# Altium schematic sheet [2]-CPLD-XC95144.SchDoc
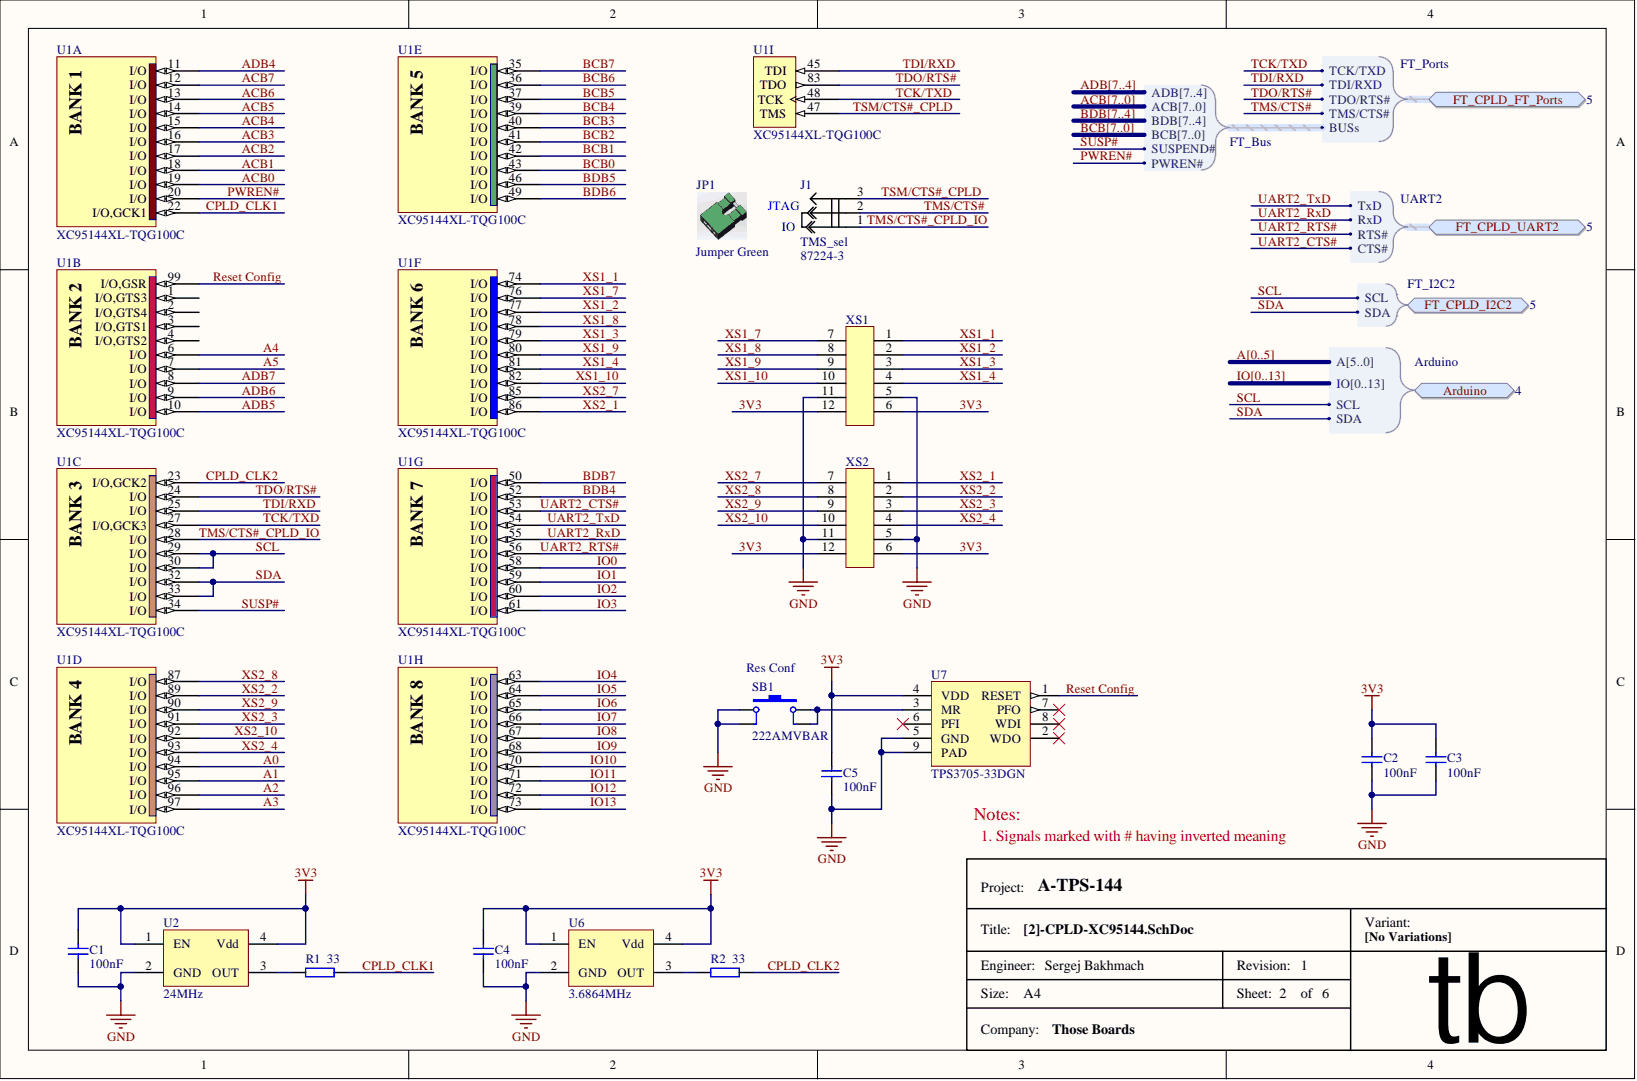
[diagram p0: [2]-CPLD-XC95144.SchDoc]

## Parts
C1  value 100nF  [C0603]  (hidden comment: CAP)
C2  value 100nF  [C0603]  (hidden comment: CAP)
C3  value 100nF  [C0603]  (hidden comment: CAP)
C4  value 100nF  [C0603]  (hidden comment: CAP)
C5  value 100nF  [C0603]  (hidden comment: CAP)
J1  TMS_sel  value 87224-3  Manufacturer Part: 87224-3  [Jumper_3pin_green]
JP1  value Jumper Green  Supplier Part: Jumper  (hidden comment: VCC_sel)  (mechanical)
R1  value 33  [0603_RESISTOR]  (hidden comment: Resistor)
R2  value 33  [0603_RESISTOR]  (hidden comment: Resistor)
SB1  Res Conf  value 222AMVBAR  Manufacturer Part: 222AMVBAR  [Key 6.2x6.2]
U1  value XC95144XL-TQG100C  Supplier Part: XC95144XL-TQG100C  [TQ100 - XC95144XL]  (hidden comment: XC95144XL-SQ100)  (parts A,B,C,D,E,F,G,H,I)
U2  value 24MHz  [OSC_5x7]  (hidden comment: OSC_Crystal Oscillator)
U6  value 3.6864MHz  Manufacturer Part: 3.6864MHz  [OSC_5x7]  (hidden comment: OSC_Crystal Oscillator)
U7  TPS3705-33DGN  [DGNR_pwrpad]
XS1  DG2_conn_pmod_angle_m  [IDC2X6M_PMOD]  (value not drawn)
XS2  DG2_conn_pmod_angle_m  [IDC2X6M_PMOD]  (value not drawn)

## Ports
ARDUINO, ARDUINO.A0, ARDUINO.A1, ARDUINO.A2, ARDUINO.A3, ARDUINO.A4, ARDUINO.A5, ARDUINO.A[5..0], ARDUINO.IO0, ARDUINO.IO1, ARDUINO.IO2, ARDUINO.IO3, ARDUINO.IO4, ARDUINO.IO5, ARDUINO.IO6, ARDUINO.IO7, ARDUINO.IO8, ARDUINO.IO9, ARDUINO.IO10, ARDUINO.IO11, ARDUINO.IO12, ARDUINO.IO13, ARDUINO.IO[0..13], ARDUINO.SCL, ARDUINO.SDA, FT_CPLD_FT_PORTS, FT_CPLD_FT_PORTS.BUSS.ACB0, FT_CPLD_FT_PORTS.BUSS.ACB1, FT_CPLD_FT_PORTS.BUSS.ACB2, FT_CPLD_FT_PORTS.BUSS.ACB3, FT_CPLD_FT_PORTS.BUSS.ACB4, FT_CPLD_FT_PORTS.BUSS.ACB5, FT_CPLD_FT_PORTS.BUSS.ACB6, FT_CPLD_FT_PORTS.BUSS.ACB7, FT_CPLD_FT_PORTS.BUSS.ACB[7..0], FT_CPLD_FT_PORTS.BUSS.ADB4, FT_CPLD_FT_PORTS.BUSS.ADB5, FT_CPLD_FT_PORTS.BUSS.ADB6, FT_CPLD_FT_PORTS.BUSS.ADB7, FT_CPLD_FT_PORTS.BUSS.ADB[7..4], FT_CPLD_FT_PORTS.BUSS.BCB0, FT_CPLD_FT_PORTS.BUSS.BCB1, FT_CPLD_FT_PORTS.BUSS.BCB2, FT_CPLD_FT_PORTS.BUSS.BCB3, FT_CPLD_FT_PORTS.BUSS.BCB4, FT_CPLD_FT_PORTS.BUSS.BCB5, FT_CPLD_FT_PORTS.BUSS.BCB6, FT_CPLD_FT_PORTS.BUSS.BCB7, FT_CPLD_FT_PORTS.BUSS.BCB[7..0], FT_CPLD_FT_PORTS.BUSS.BDB4, FT_CPLD_FT_PORTS.BUSS.BDB5, FT_CPLD_FT_PORTS.BUSS.BDB6, FT_CPLD_FT_PORTS.BUSS.BDB7, FT_CPLD_FT_PORTS.BUSS.BDB[7..4], FT_CPLD_FT_PORTS.BUSS.PWREN#, FT_CPLD_FT_PORTS.BUSS.SUSPEND#, FT_CPLD_FT_PORTS.TCK/TXD, FT_CPLD_FT_PORTS.TDI/RXD, FT_CPLD_FT_PORTS.TDO/RTS#, FT_CPLD_FT_PORTS.TMS/CTS#, FT_CPLD_I2C2, FT_CPLD_I2C2.SCL, FT_CPLD_I2C2.SDA, FT_CPLD_UART2, FT_CPLD_UART2.CTS#, FT_CPLD_UART2.RTS#, FT_CPLD_UART2.RXD, FT_CPLD_UART2.TXD

## Nets
GND: C1.1 C2.1 C3.1 C4.1 C5.1 SB1.1 SB1.3 U2.2(GND) U6.2(GND) U7.5(GND) U7.9(PAD) XS1.5 XS1.11 XS2.5 XS2.11
3V3: C1.2 C2.2 C3.2 C4.2 C5.2 U2.1(EN) U2.4(Vdd) U6.1(EN) U6.4(Vdd) U7.4(VDD) XS1.6 XS1.12 XS2.6 XS2.12
A0: U1.94(I/O) ; port ARDUINO  [also labelled A]
A1: U1.95(I/O) ; port ARDUINO  [also labelled A]
A2: U1.96(I/O) ; port ARDUINO  [also labelled A]
A3: U1.97(I/O) ; port ARDUINO  [also labelled A]
A4: U1.6(I/O) ; port ARDUINO  [also labelled A]
A5: U1.7(I/O) ; port ARDUINO  [also labelled A]
ACB0: U1.19(I/O) ; port FT_CPLD_FT_PORTS  [also labelled ACB]
ACB1: U1.18(I/O) ; port FT_CPLD_FT_PORTS  [also labelled ACB]
ACB2: U1.17(I/O) ; port FT_CPLD_FT_PORTS  [also labelled ACB]
ACB3: U1.16(I/O) ; port FT_CPLD_FT_PORTS  [also labelled ACB]
ACB4: U1.15(I/O) ; port FT_CPLD_FT_PORTS  [also labelled ACB]
ACB5: U1.14(I/O) ; port FT_CPLD_FT_PORTS  [also labelled ACB]
ACB6: U1.13(I/O) ; port FT_CPLD_FT_PORTS  [also labelled ACB]
ACB7: U1.12(I/O) ; port FT_CPLD_FT_PORTS  [also labelled ACB]
ADB4: U1.11(I/O) ; port FT_CPLD_FT_PORTS  [also labelled ADB]
ADB5: U1.10(I/O) ; port FT_CPLD_FT_PORTS  [also labelled ADB]
ADB6: U1.9(I/O) ; port FT_CPLD_FT_PORTS  [also labelled ADB]
ADB7: U1.8(I/O) ; port FT_CPLD_FT_PORTS  [also labelled ADB]
BCB0: U1.43(I/O) ; port FT_CPLD_FT_PORTS  [also labelled BCB]
BCB1: U1.42(I/O) ; port FT_CPLD_FT_PORTS  [also labelled BCB]
BCB2: U1.41(I/O) ; port FT_CPLD_FT_PORTS  [also labelled BCB]
BCB3: U1.40(I/O) ; port FT_CPLD_FT_PORTS  [also labelled BCB]
BCB4: U1.39(I/O) ; port FT_CPLD_FT_PORTS  [also labelled BCB]
BCB5: U1.37(I/O) ; port FT_CPLD_FT_PORTS  [also labelled BCB]
BCB6: U1.36(I/O) ; port FT_CPLD_FT_PORTS  [also labelled BCB]
BCB7: U1.35(I/O) ; port FT_CPLD_FT_PORTS  [also labelled BCB]
BDB4: U1.52(I/O) ; port FT_CPLD_FT_PORTS  [also labelled BDB]
BDB5: U1.46(I/O) ; port FT_CPLD_FT_PORTS  [also labelled BDB]
BDB6: U1.49(I/O) ; port FT_CPLD_FT_PORTS  [also labelled BDB]
BDB7: U1.50(I/O) ; port FT_CPLD_FT_PORTS  [also labelled BDB]
CPLD_CLK1: R1.2 U1.22(I/O,GCK1)
CPLD_CLK2: R2.2 U1.23(I/O,GCK2)
IO0: U1.58(I/O) ; port ARDUINO  [also labelled IO]
IO1: U1.59(I/O) ; port ARDUINO  [also labelled IO]
IO2: U1.60(I/O) ; port ARDUINO  [also labelled IO]
IO3: U1.61(I/O) ; port ARDUINO  [also labelled IO]
IO4: U1.63(I/O) ; port ARDUINO  [also labelled IO]
IO5: U1.64(I/O) ; port ARDUINO  [also labelled IO]
IO6: U1.65(I/O) ; port ARDUINO  [also labelled IO]
IO7: U1.66(I/O) ; port ARDUINO  [also labelled IO]
IO8: U1.67(I/O) ; port ARDUINO  [also labelled IO]
IO9: U1.68(I/O) ; port ARDUINO  [also labelled IO]
IO10: U1.70(I/O) ; port ARDUINO  [also labelled IO]
IO11: U1.71(I/O) ; port ARDUINO  [also labelled IO]
IO12: U1.72(I/O) ; port ARDUINO  [also labelled IO]
IO13: U1.73(I/O) ; port ARDUINO  [also labelled IO]
PWREN#: U1.20(I/O) ; port FT_CPLD_FT_PORTS
RESET CONFIG: U1.99(I/O,GSR) U7.1(RESET)
SCL: U1.29(I/O) U1.30(I/O) ; port FT_CPLD_I2C2
SDA: U1.32(I/O) U1.33(I/O) ; port FT_CPLD_I2C2
SUSP#: U1.34(I/O) ; port FT_CPLD_FT_PORTS
TCK/TXD: U1.27(I/O,GCK3) U1.48(TCK) ; port FT_CPLD_FT_PORTS
TDI/RXD: U1.25(I/O) U1.45(TDI) ; port FT_CPLD_FT_PORTS
TDO/RTS#: U1.24(I/O) U1.83(TDO) ; port FT_CPLD_FT_PORTS
TMS/CTS#: J1.2 ; port FT_CPLD_FT_PORTS
TMS/CTS#_CPLD_IO: J1.1 U1.28(I/O)
TSM/CTS#_CPLD: J1.3 U1.47(TMS)
UART2_CTS#: U1.53(I/O) ; port FT_CPLD_UART2
UART2_RTS#: U1.56(I/O) ; port FT_CPLD_UART2
UART2_RXD: U1.55(I/O) ; port FT_CPLD_UART2
UART2_TXD: U1.54(I/O) ; port FT_CPLD_UART2
XS1_1: U1.74(I/O) XS1.1
XS1_2: U1.77(I/O) XS1.2
XS1_3: U1.79(I/O) XS1.3
XS1_4: U1.81(I/O) XS1.4
XS1_7: U1.76(I/O) XS1.7
XS1_8: U1.78(I/O) XS1.8
XS1_9: U1.80(I/O) XS1.9
XS1_10: U1.82(I/O) XS1.10
XS2_1: U1.86(I/O) XS2.1
XS2_2: U1.89(I/O) XS2.2
XS2_3: U1.91(I/O) XS2.3
XS2_4: U1.93(I/O) XS2.4
XS2_7: U1.85(I/O) XS2.7
XS2_8: U1.87(I/O) XS2.8
XS2_9: U1.90(I/O) XS2.9
XS2_10: U1.92(I/O) XS2.10
NetR1_1: R1.1 U2.3(OUT)
NetR2_1: R2.1 U6.3(OUT)
NetSB1_2: SB1.2 SB1.4 U7.3(MR)

Not connected: U1 1(I/O,GTS3) 2(I/O,GTS4) 3(I/O,GTS1) 4(I/O,GTS2); U7 2(WDO) 6(PFI) 7(PFO) 8(WDI)

## Library data of the parts
C1-C5 = CAP: Manufacturer: Vishay / Vitramon; Manufacturer Part: VJ0603Y104JXJPW1BC; Type of Component: Ceramic Capacitor; Component Kind: Standard; Manufacturer Link: http://www.vishay.com/product?docid=28548; Package / Case: 0603; Supplier: mouser; Supplier Link: http://www.mouser.com/ProductDetail/Vishay/VJ0603Y104JXJPW1BC/?qs=%2fha2pyFaduidGoO0X7aK7Xgs%2fjxfhnLiK6SRw4Lz0%252bZK3PJ8DddTrQ%3d%3d; Supplier Part: 77-VJ0603Y104JXJPBC; Library Name: RPI-VITA.IntLib
J1 = jumper_3pin: Manufacturer: TE Connectivity / AMP; Type of Component: Jumper; Component Kind: Standard; Manufacturer Link: http://www.te.com/usa-en/product-87224-3.html#pdp-related-products-inner; Package / Case: Header 1 x 3; Supplier: mouser; Supplier Link: http://www.mouser.ee/ProductDetail/TE-Connectivity-AMP/87224-3/?qs=sGAEpiMZZMs%252bGHln7q6pm48SVpWlpfsErguO%2fp0873w%3d; Supplier Part: 571-872243; Library Name: RPI-VITA.IntLib
JP1 = jumper green: Manufacturer: Great Electric; Manufacturer Part: Jumper Cap Shunts Short Circuit Cap; Package / Case: 2.54mm; Supplier: aliexpress.com; Supplier Link: http://www.aliexpress.com/item/500pcs-lot-Asssorted-Color-2-54mm-Standard-Circuit-Board-Jumper-Cap-Shunts-Short-Circuit-Cap-CGKCH119/32344305619.html?spm=2114.03010208.3.80.nPPmoy&ws_ab_test=searchweb201556_1,searchweb201644_1_505_506_503_504_502_10001_10002_10017_10010_10005_10011_10006_10003_10004_10009_10007_10008,searchweb201560_5,searchweb1451318400_-1,searchweb1451318411_6452&btsid=16d11777-edde-4755-b8b5-9adacb495b09; Type of Component: Jumper; Library Name: RPI-VITA.IntLib
R1 R2 = Resistor: Manufacturer: Vishay / Dale; Manufacturer Part: CRCW060333R0FKEA; Component Kind: Standard; Manufacturer Link: http://www.vishay.com/product?docid=20035; Package / Case: 0603; Supplier: mouser; Supplier Link: http://www2.mouser.com/ProductDetail/Vishay-Dale/CRCW060333R0FKEA/?qs=sGAEpiMZZMvdGkrng054t1X0qgDPqELUwAjRIFbU4%252bo%3d; Supplier Part: 71-CRCW0603-33-E3; Type of Component: Resistor; Library Name: RPI-VITA.IntLib
SB1 = SB_Tactile: Tactile Switches; Manufacturer: E-Switch; Type of Component: Tact Switch; Component Kind: Standard; Package / Case: 6.2 x 6.2 x 5; Supplier: mouser; Supplier Link: http://www.mouser.ee/ProductDetail/CTS-Electronic-Components/222AMVBAR/?qs=sGAEpiMZZMsgGjVA3toVBB5GWLGpesfgV6sVMBFzGDw%3d; Supplier Part: 774-222AMVBAR; Library Name: RPI-VITA.IntLib
U1 = XC95144XL-SQ100: The XC95144XL is a 3.3V CPLD targeted for high-performance,  low-voltage applications in leading-edge communications  and computing systems.; Manufacturer: Xilinx Inc; Manufacturer Part: XC95144XL-10TQG100C; Component Kind: Standard; Manufacturer Link: http://xgoogle.xilinx.com/search?output=xml_no_dtd&ie=UTF-8&oe=UTF-8&client=xilinx&proxystylesheet=xilinx&site=EntireSite&filter=0&num=200&sort=date:D:L:d1&getfields=*&show_dynamic_navigation=1&requiredfields=-Archived%3Atrue&q=XC95144XL-10TQG100C&as_q=; Package / Case: TQG-100C; Supplier: HK-Ada; Type of Component: CPLD; Library Name: RPI-VITA.IntLib
U2 U6 = OSC_Crystal Oscillator: Manufacturer: KKQ; Type of Component: Clock Oscillator; Component Kind: Standard; Package / Case: 5 mm x 7 mm; Supplier: aliexpress.com; Library Name: RPI-VITA.IntLib
  U2: Supplier Link: http://fr.aliexpress.com/item/Free-shipping-24-000MHZ-24-000M-24-000-20Pcs-Lot-5070-7050-5-7-SMD-4Pin/1254133788.html; Supplier Part: 24MHz 4 pin
  U6: Supplier Link: http://aliexpress.com/item/100PCS-Original-NEW-3-6864MHZ-3-6864M-3-6864-5-7-4P-5070-OSC-5-00mm/32275012196.html?detailNewVersion=1; Supplier Part: 3.6864MHz 4 pin
U7 = TPS3705DGNR: Processor supervisory circuits with power-fail; Manufacturer: TI; Component Kind: Standard; Manufacturer Link: http://www.ti.com/product/TPS3705-33?CMP=conv-poasamples#samplebuy; Manufacturer Part: TPS3705-33DGN; Package / Case: MSOP-8; Supplier: mouser; Supplier Link: http://www.mouser.ee/search/ProductDetail.aspx?Texas-Instruments%2fTPS3705-33DGN%2f&qs=6gY4t2uohMxyZA1EcEsrJg%3d%3d; Supplier Part: 595-TPS3705-33DGN; Type of Component: Supervisor; Value: TPS3705-33DGN; Library Name: RPI-VITA.IntLib
XS1 XS2 = DG2_conn_pmod_angle_m: Manufacturer: China; Type of Component: PMOD Right Angle Male; Value: PMOD_Right_Angle_Male; Component Kind: Standard; Package / Case: Header 2x6; Supplier: HK-Ada; Supplier Part: PMOD_Right_Angle_Male; Library Name: RPI-VITA.IntLib
